# Altium schematic sheet Sheet1.SchDoc(Sheet1)
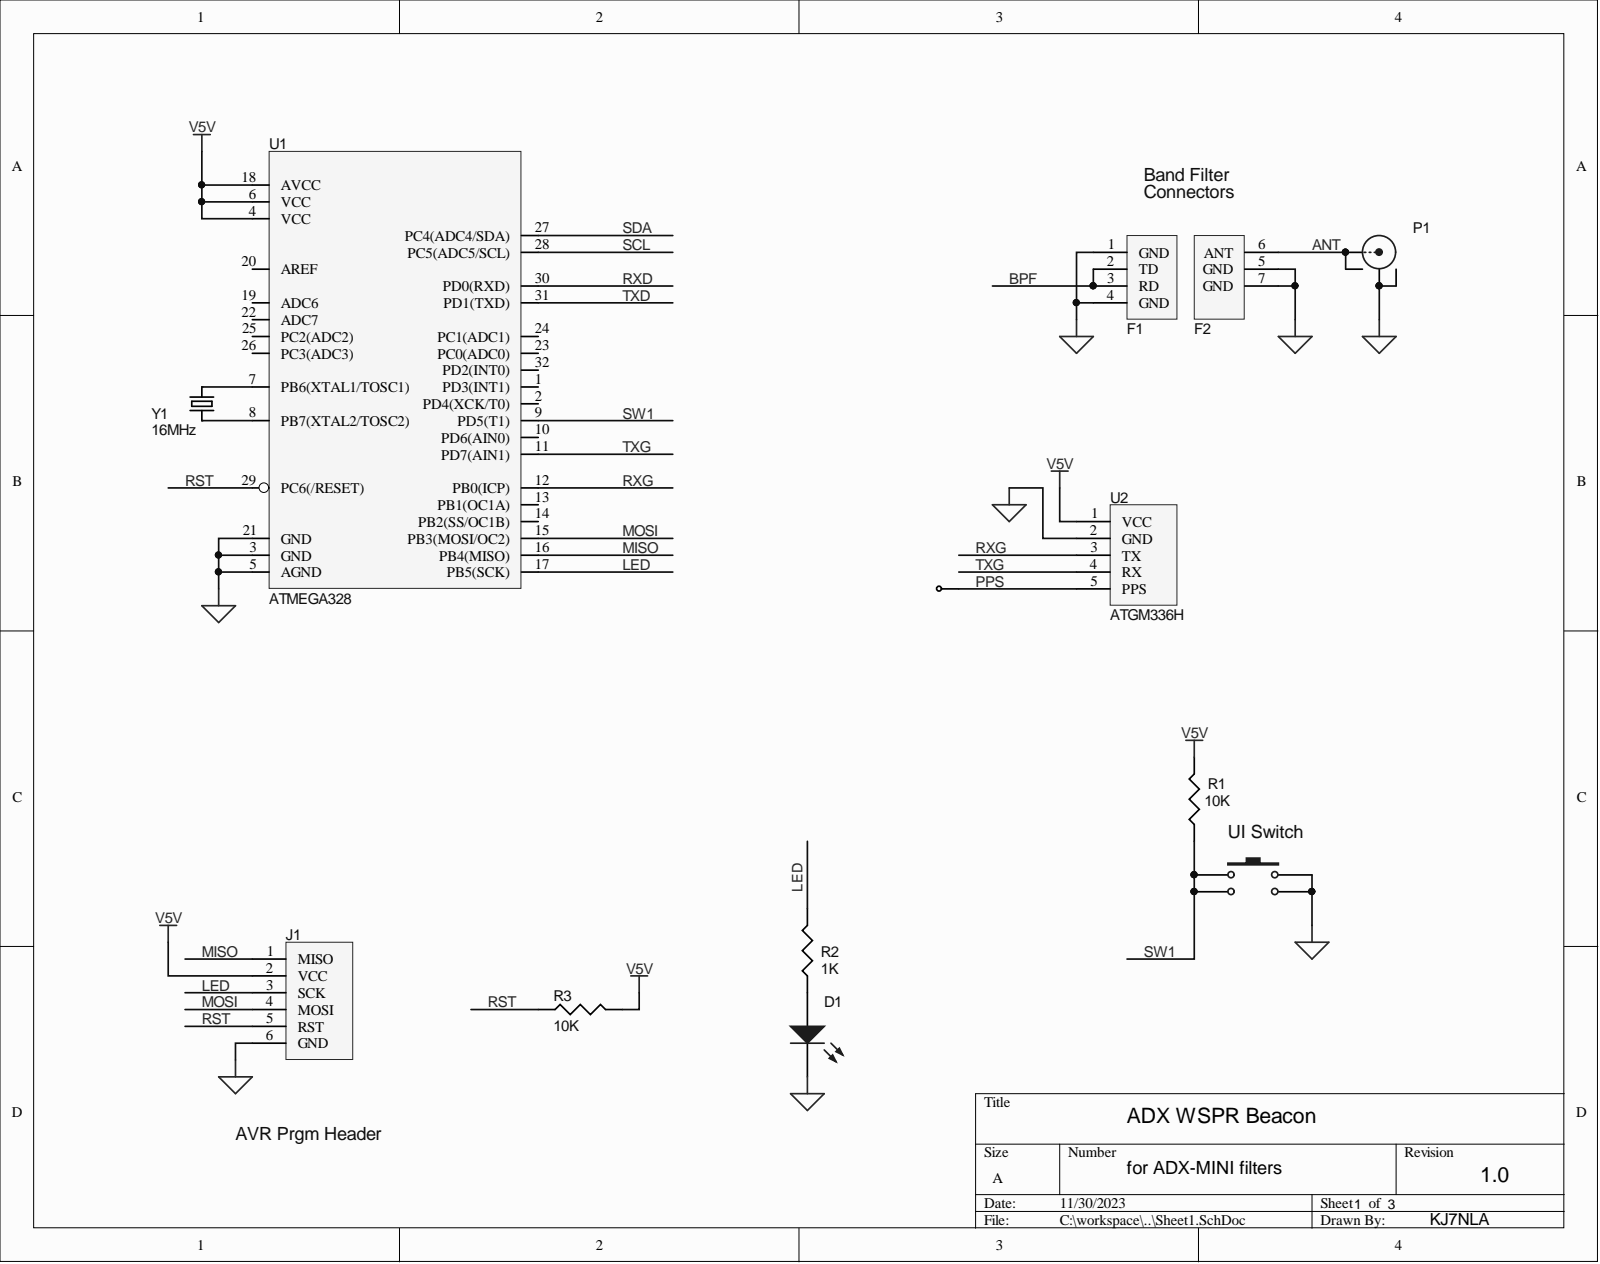
[diagram p0: Sheet1.SchDoc]

## Parts
D1  LED  [3-0603]  (value not drawn)
F1  LPF Module  [RFMODULE-A]  (value not drawn)
F2  LPF Module  [RFMODULE-B]  (value not drawn)
J1  Header AVR  [CONN2X3A]  (value not drawn)
P1  BNC  [CONN-BNC-2]  (value not drawn)
R1  value 10K  [3-0603]  (hidden comment: 10.0K)
R2  1K  [3-0603]
R3  value 10K  [3-0603]  (hidden comment: 10.0K)
S1  Push Button  [PB1]  (value not drawn)
TP1  Testpoint  [Testpoint]  (value not drawn)
U1  ATMEGA328  [ATMEG328]
U2  ATGM336H  [GPS]
Y1  16MHz  [Xtal_SMT1]

## Nets
GND: D1.2 F1.1(GND) F1.4(GND) F2.5 F2.7 J1.6(GND) P1.2 P1.6 S1.3 S1.4 U1.3(GND) U1.5(AGND) U1.21(GND) U2.2(GND) Y1.4
V5V: J1.2(VCC) R1.2 R3.2 U1.4(VCC) U1.6(VCC) U1.18(AVCC) U2.1(VCC)
ANT: F2.6 P1.1 P1.5
BPF: F1.2(TD) F1.3(RD)
LED: J1.3(SCK) R2.2 U1.17(PB5(SCK))
MISO: J1.1(MISO) U1.16(PB4(MISO))
MOSI: J1.4(MOSI) U1.15(PB3(MOSI/OC2))
PPS: TP1.1 U2.5(PPS)
RST: J1.5(RST) R3.1 U1.29(PC6(/RESET))
RXD: U1.30(PD0(RXD))
RXG: U1.12(PB0(ICP)) U2.3(TX)
SCL: U1.28(PC5(ADC5/SCL))
SDA: U1.27(PC4(ADC4/SDA))
SW1: R1.1 S1.1 S1.2 U1.9(PD5(T1))
TXD: U1.31(PD1(TXD))
TXG: U1.11(PD7(AIN1)) U2.4(RX)
NetD1_1: D1.1 R2.1
NetU1_7: U1.7(PB6(XTAL1/TOSC1)) Y1.3
NetU1_8: U1.8(PB7(XTAL2/TOSC2)) Y1.1

Not connected: U1 1(PD3(INT1)) 2(PD4(XCK/T0)) 10(PD6(AIN0)) 13(PB1(OC1A)) 14(PB2(SS/OC1B)) 19(ADC6) 20(AREF) 22(ADC7) 23(PC0(ADC0)) 24(PC1(ADC1)) 25(PC2(ADC2)) 26(PC3(ADC3)) 32(PD2(INT0))

## Library data of the parts
D1 = LED1: Supplier Part Number 1: NA; Supplier 1: Digi-Key; Library Name: Miscellaneous Devices.IntLib; JLCC Part Number: C434419; Component Kind: Standard
F1 = LPF-A: Supplier Part Number 1: NA; Supplier 1: NA; JLCC Part Number: NA; Component Kind: Standard; Library Name: usdx.SchLib
F2 = LPF-B: Supplier Part Number 1: NA; Supplier 1: NA; JLCC Part Number: NA; Component Kind: Standard; Library Name: usdx.SchLib
R1-R3 = Resistor: Supplier Part Number 1: NA; Supplier 1: Digi-Key; Component Kind: Standard; Library Name: usdx.SchLib
  R1 R3: JLCC Part Number: C191318
  R2: Value: 1K; JLCC Part Number: C14676
S1 = SW-PB: Supplier Part Number 1: NA; Supplier 1: Digi-Key; JLCC Part Number: C221880; Component Kind: Standard; Library Name: usdx.SchLib
U1 = ATMEGA328-SMT: Supplier Part Number 1: NA; Supplier 1: Digi-Key; JLCC Part Number: C14877; Component Kind: Standard; Library Name: usdx.SchLib
U2 = GPS: Supplier Part Number 1: NA; Supplier 1: Digi-Key; JLCC Part Number: NA; Component Kind: Standard; Library Name: usdx.SchLib
Y1 = XTAL-SMT: Value: 16MHz; Supplier Part Number 1: NA; Supplier 1: Digi-Key; JLCC Part Number: C13738; Component Kind: Standard; Library Name: usdx.SchLib
J1 = Header AVR: Supplier Part Number 1: NA; Supplier 1: Digi-Key; JLCC Part Number: NA; Component Kind: Standard; Library Name: usdx.SchLib
P1 = BNC: Supplier Part NUmber 1: NA; Supplier 1: Digi-Key; JLCC Part Number: NA; Component Kind: Standard; Library Name: usdx.SchLib
TP1 = Testpoint: Supplier Part Number 1: NA; Supplier 1: NA; JLCC Part Number: NA; Component Kind: Standard; Library Name: usdx.SchLib
